# Altium schematic sheet SH_SW3_V1.0.SchDoc
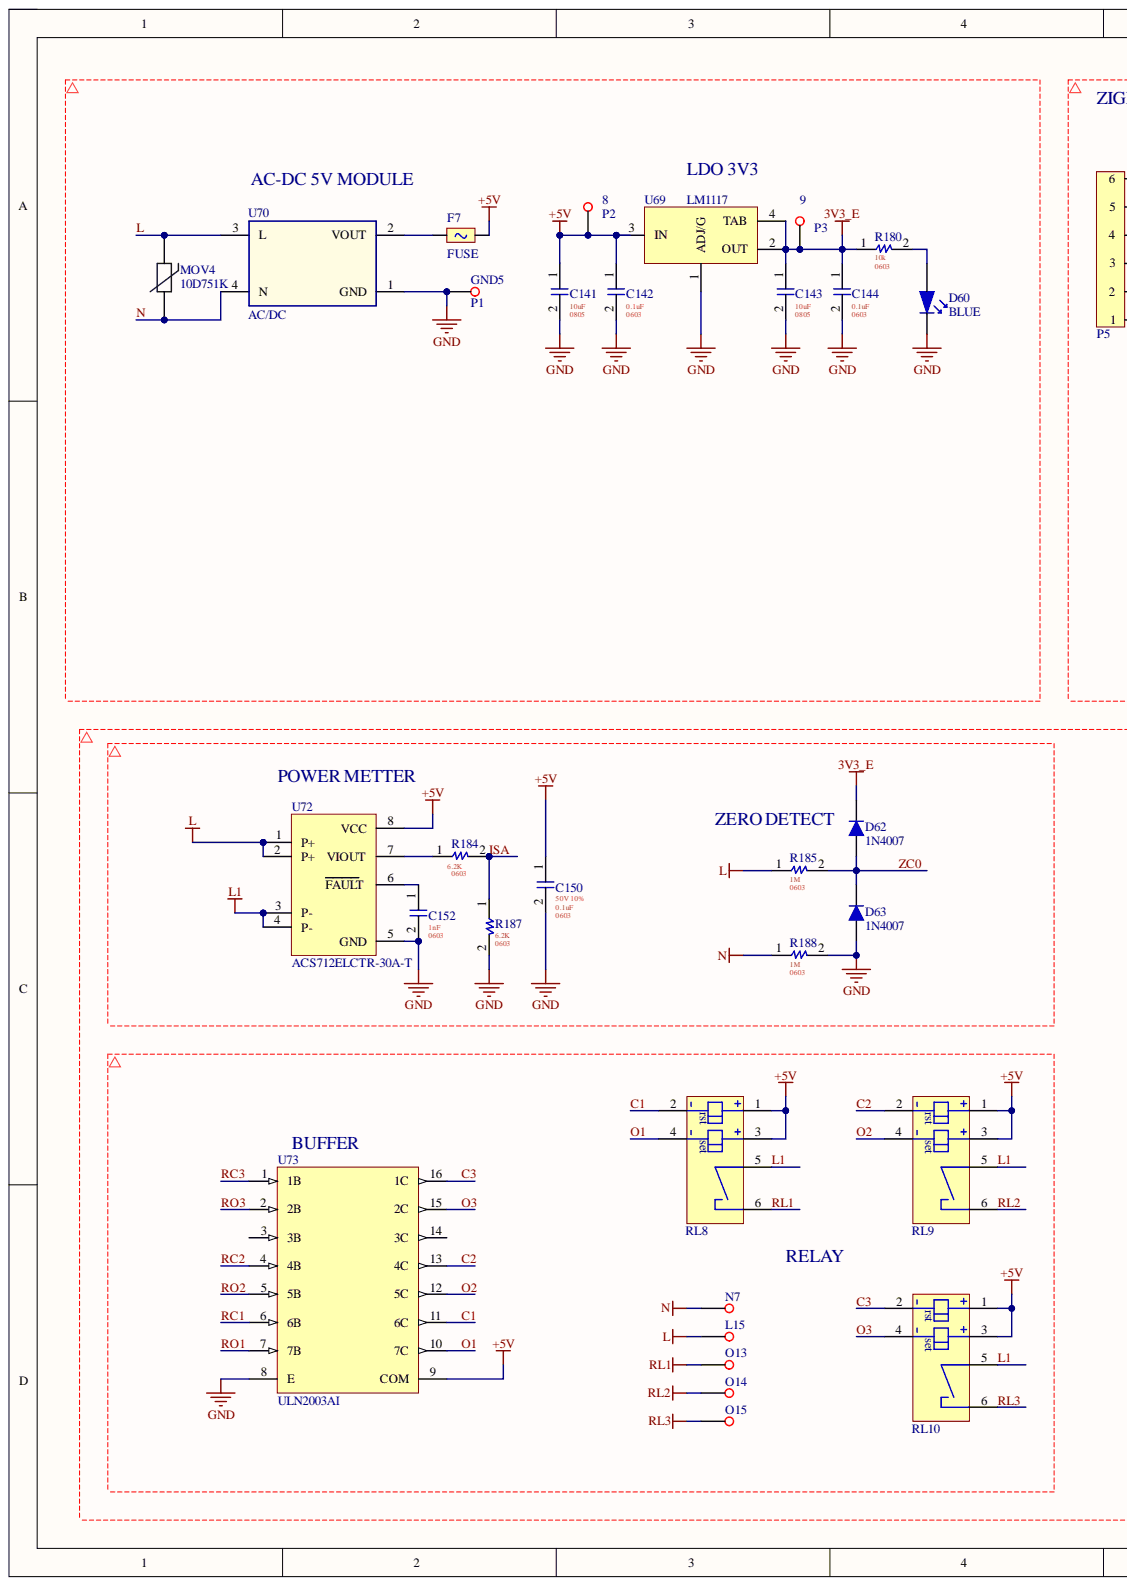
[diagram p0: SH_SW3_V1.0.SchDoc, left]
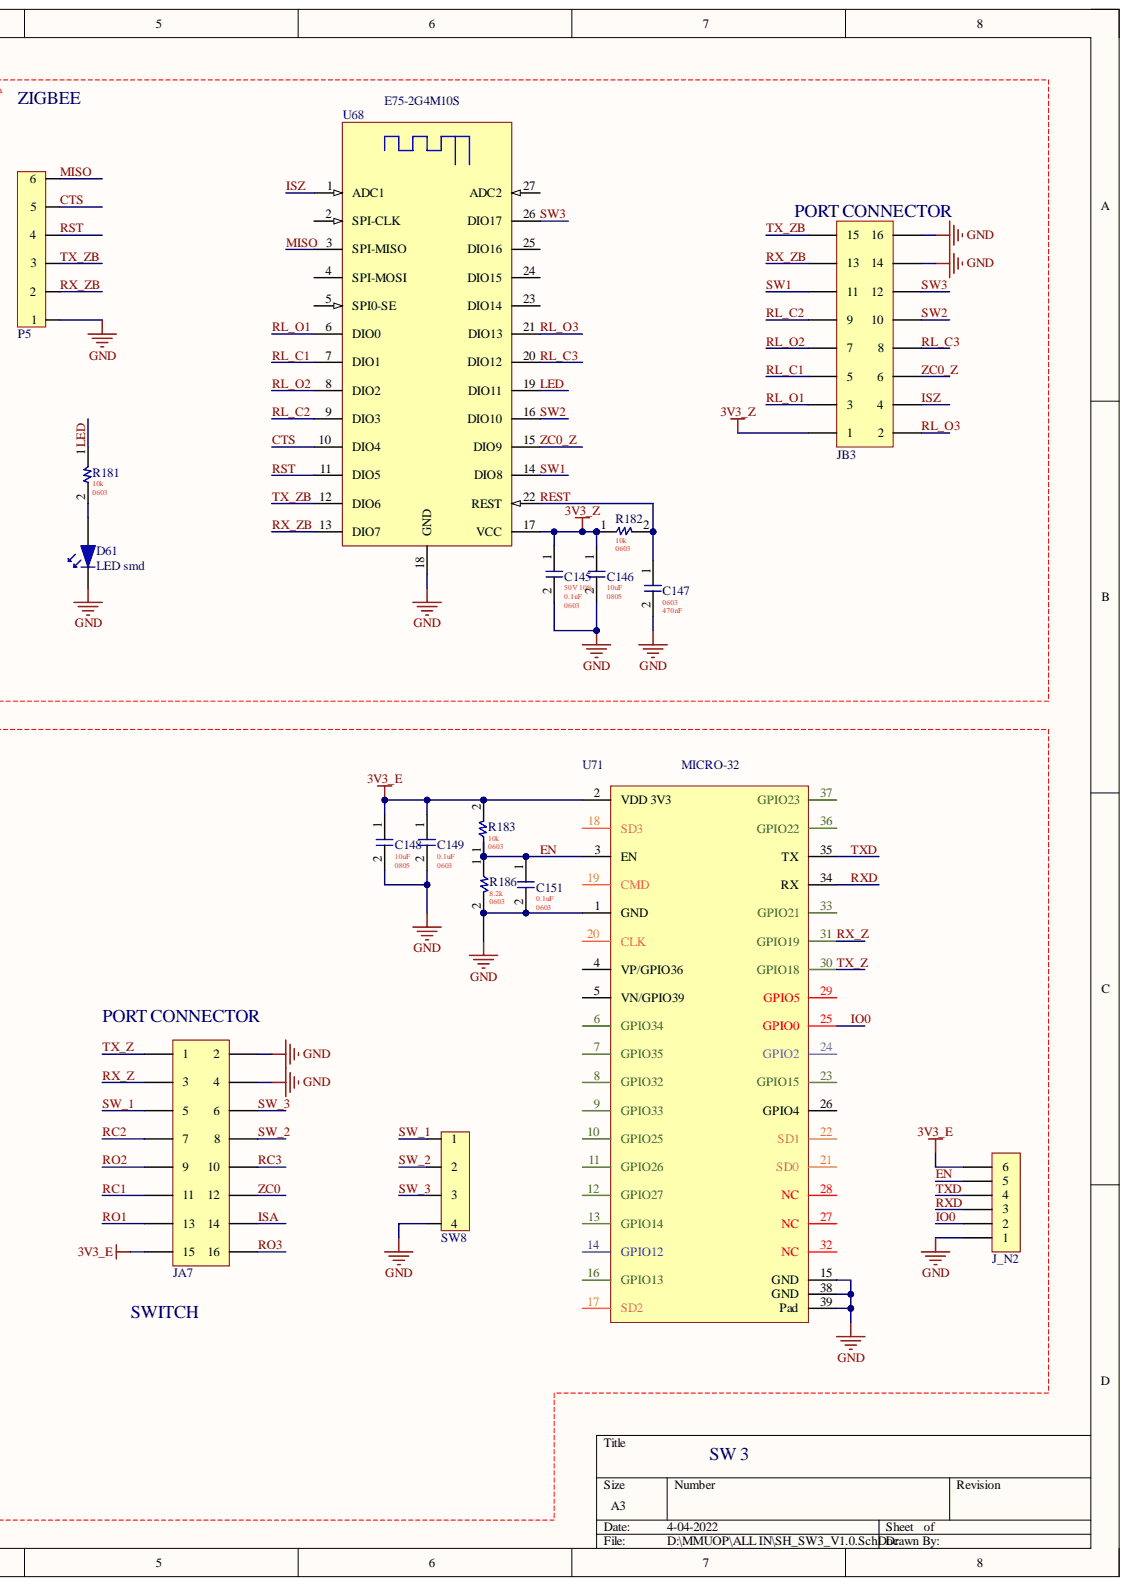
[diagram p1: SH_SW3_V1.0.SchDoc, right]

## Parts
8  P2  [TEXT_POINT]
9  P3  [TEXT_POINT]
C141  10uF  FP: 0805  [0805 C]
C142  0.1uF  FP: 0603  [0603 C]
C143  10uF  FP: 0805  [0805 C]
C144  0.1uF  FP: 0603  [0603 C]
C145  0.1uF  FP: 0603  VOLTAGE + TOLE: 50V 10%  [0603 C]
C146  10uF  FP: 0805  [0805 C]
C147  470nF  FP: 0603  [0603 C]
C148  10uF  FP: 0805  [0805 C]
C149  0.1uF  FP: 0603  [0603 C]
C150  0.1uF  FP: 0603  VOLTAGE + TOLE: 50V 10%  [0603 C]
C151  0.1uF  FP: 0603  [0603 C]
C152  1nF  FP: 0603  [0603 C]
D60  BLUE  [Led 1206]
D61  LED smd  [Led 1206]
D62  1N4007  [SOD-123]
D63  1N4007  [SOD-123]
F7  FUSE  [1812]
GND5  P1  [TEXT_POINT]
J_N2  P6  [P6 - 1.27MM]  (value not drawn)
JA7  rao doi  [P2X8 - 2.0mm - female - SMD]  (value not drawn)
JB3  rao doi  [P2X8 - 2.0mm - SMD]  (value not drawn)
L15  P1  [P1]  (value not drawn)
MOV4  10D751K  [MOV]
N7  P1  [P1]  (value not drawn)
O13  P1  [P1]  (value not drawn)
O14  P1  [P1]  (value not drawn)
O15  P1  [P1]  (value not drawn)
P5  P6  [P6 - 1.27MM]  (value not drawn)
R180  10k  FP: 0603  [0603 R]
R181  10k  FP: 0603  [0603 R]
R182  10k  FP: 0603  [0603 R]
R183  10k  FP: 0603  [0603 R]
R184  value 6.2K  FP: 0603  [0603 R]  (hidden comment: 8.2k)
R185  1M  FP: 0603  [0603 R]
R186  8.2k  FP: 0603  [0603 R]
R187  value 6.2K  FP: 0603  [0603 R]  (hidden comment: 8.2k)
R188  1M  FP: 0603  [0603 R]
RL8  RELAY CHOT  [HFE60]  (value not drawn)
RL9  RELAY CHOT  [HFE60]  (value not drawn)
RL10  RELAY CHOT  [HFE60]  (value not drawn)
SW8  P4  [P4 2.54 RA header]  (value not drawn)
U68  E75-2G4M10S  [ebyte - E75-2G4M10S]
U69  LM1117  [SOT23-4]
U70  AC/DC  [AC-DC 5V K4H24]
U71  MICRO-32  [ESP MICRO 32]
U72  ACS712ELCTR-30A-T  [SOIC 8]
U73  ULN2003AI  [SOIC 16]

## Nets
3V3_E: 9.1 C143.1 C144.1 C148.1 C149.1 D62.2 J_N2.6 JA7.15 R180.1 R183.2 U69.2(OUT) U69.4(TAB) U71.2(VDD_3V3)
3V3_Z: C145.1 C146.1 JB3.1 R182.1 U68.17(VCC)
+5V: 8.1 C141.1 C142.1 C150.1 F7.2 RL8.1 RL8.3 RL9.1 RL9.3 RL10.1 RL10.3 U69.3(IN) U72.8(VCC) U73.9(COM)
GND: C141.2 C142.2 C143.2 C144.2 C145.2 C146.2 C147.2 C148.2 C149.2 C150.2 C151.2 C152.2 D60.2 D61.2 D63.1 GND5.1 J_N2.1 JA7.2 JA7.4 JB3.14 JB3.16 P5.1 R186.2 R187.2 R188.2 SW8.4 U68.18(GND) U69.1(ADJ/G) U70.1(GND) U71.1(GND) U71.15(GND) U71.38(GND) U71.39(Pad) U72.5(GND) U73.8(E)
C1: RL8.2 U73.11(6C)
C2: RL9.2 U73.13(4C)
C3: RL10.2 U73.16(1C)
CTS: P5.5 U68.10(DIO4)
EN: C151.1 J_N2.5 R183.1 R186.1 U71.3(EN)
IO0: J_N2.2 U71.25(GPIO0)
ISA: JA7.14 R184.2 R187.1
ISZ: JB3.4 U68.1(ADC1)
L: L15.1 MOV4.2 R185.1 U70.3(L) U72.1(P+) U72.2(P+)
L1: RL8.5 RL9.5 RL10.5 U72.3(P-) U72.4(P-)
LED: R181.1 U68.19(DIO11)
MISO: P5.6 U68.3(SPI-MISO)
N: MOV4.1 N7.1 R188.1 U70.4(N)
O1: RL8.4 U73.10(7C)
O2: RL9.4 U73.12(5C)
O3: RL10.4 U73.15(2C)
RC1: JA7.11 U73.6(6B)
RC2: JA7.7 U73.4(4B)
RC3: JA7.10 U73.1(1B)
REST: C147.1 R182.2 U68.22(REST)
RL1: O13.1 RL8.6
RL2: O14.1 RL9.6
RL3: O15.1 RL10.6
RL_C1: JB3.5 U68.7(DIO1)
RL_C2: JB3.9 U68.9(DIO3)
RL_C3: JB3.8 U68.20(DIO12)
RL_O1: JB3.3 U68.6(DIO0)
RL_O2: JB3.7 U68.8(DIO2)
RL_O3: JB3.2 U68.21(DIO13)
RO1: JA7.13 U73.7(7B)
RO2: JA7.9 U73.5(5B)
RO3: JA7.16 U73.2(2B)
RST: P5.4 U68.11(DIO5)
RX_Z: JA7.3 U71.31(GPIO19)
RX_ZB: JB3.13 P5.2 U68.13(DIO7)
RXD: J_N2.3 U71.34(RX)
SW1: JB3.11 U68.14(DIO8)
SW2: JB3.10 U68.16(DIO10)
SW3: JB3.12 U68.26(DIO17)
SW_1: JA7.5 SW8.1
SW_2: JA7.8 SW8.2
SW_3: JA7.6 SW8.3
TX_Z: JA7.1 U71.30(GPIO18)
TX_ZB: JB3.15 P5.3 U68.12(DIO6)
TXD: J_N2.4 U71.35(TX)
ZC0: D62.1 D63.2 JA7.12 R185.2
ZC0_Z: JB3.6 U68.15(DIO9)
NetC152_1: C152.1 U72.6(FAULT)
NetD60_1: D60.1 R180.2
NetD61_1: D61.1 R181.2
NetF7_1: F7.1 U70.2(VOUT)
NetR184_1: R184.1 U72.7(VIOUT)

Not connected: U68 2(SPI-CLK) 4(SPI-MOSI) 5(SPI0-SE) 23(DIO14) 24(DIO15) 25(DIO16) 27(ADC2); U71 4(VP/GPIO36) 5(VN/GPIO39) 6(GPIO34) 7(GPIO35) 8(GPIO32) 9(GPIO33) 10(GPIO25) 11(GPIO26) 12(GPIO27) 13(GPIO14) 14(GPIO12) 16(GPIO13) 17(SD2) 18(SD3) 19(CMD) 20(CLK) 21(SD0) 22(SD1) 23(GPIO15) 24(GPIO2) 26(GPIO4) 27(NC) 28(NC) 29(GPIO5) 32(NC) 33(GPIO21) 36(GPIO22) 37(GPIO23); U73 3(3B) 14(3C)

## Library data of the parts
8 9 = P1: Component Kind: Standard; Library Name: Kienlib.SchLib
C141 C143 C146 C148 = 0805 10uF CER: CAP CER 10uF 50V 10% 0805 SMD; VOLTAGE + TOLE: 50V 10%; Component Kind: Standard; ComponentLink1Description: New link; DATE: 04-Mar-21; Library Name: Capacitor.SchLib; PACKAGE: SMD; VALUE: 10uF; CREATOR: MMUOP
C142 C144 C149 C151 = 0603 0.1uF CER: CAP CER 0.1uF 50V 10% 0603 SMD; VOLTAGE + TOLE: 50V 10%; Component Kind: Standard; ComponentLink1Description: New link; DATE: 04-Mar-21; Library Name: Capacitor.SchLib; PACKAGE: SMD; VALUE: 0.1uF; CREATOR: MMUOP
C145 C150 = 0603 0.1uF CER: CAP CER 0.1uF 50V 10% 0603 SMD; Component Kind: Standard; ComponentLink1Description: New link; DATE: 04-Mar-21; Library Name: Capacitor.SchLib; PACKAGE: SMD; VALUE: 0.1uF; CREATOR: MMUOP
C147 = 0603 470nF CER: CAP CER 470nF 50V 10% 0603 SMD; VOLTAGE + TOLE: 50V 10%; Component Kind: Standard; ComponentLink1Description: New link; DATE: 04-Mar-21; Library Name: Capacitor.SchLib; PACKAGE: SMD; VALUE: 470nF; CREATOR: MMUOP
C152 = 0603 1nF CER: CAP CER 1nF 50V 10% 0603 SMD; VOLTAGE + TOLE: 50V 10%; Component Kind: Standard; ComponentLink1Description: New link; DATE: 04-Mar-21; Library Name: Capacitor.SchLib; PACKAGE: SMD; VALUE: 1nF; CREATOR: MMUOP
D60 = LED smd: Component Kind: Standard; Library Name: Kienlib.SchLib
D62 D63 = Diode schottky: Component Kind: Standard; Library Name: Kienlib.SchLib
JA7 JB3 = P2x8: Component Kind: Standard; Library Name: Kienlib.SchLib
MOV4 = VARISTOR: 750V; Component Kind: Standard; Library Name: Kienlib.SchLib
R180-R183 = 0603 10k 1%: 10k 1% 0603 SMD; PN: C98220; Component Kind: Standard; ComponentLink1Description: New link; DATE: 04-Mar-21; Library Name: Res.SchLib; PACKAGE: SMD; VALUE: 10k; VOLTAGE + TOLE: 1%; CREATOR: MMUOP
R184 R186 R187 = 0603 8.2k 1%: 8.2k 1% 0603 SMD; Component Kind: Standard; ComponentLink1Description: New link; DATE: 04-Mar-21; Library Name: Res.SchLib; PACKAGE: SMD; VOLTAGE + TOLE: 1%; CREATOR: MMUOP
  R186: VALUE: 8.2k
R185 R188 = 0603 1M 1%: 1M 1% 0603 SMD; Component Kind: Standard; ComponentLink1Description: New link; DATE: 04-Mar-21; Library Name: Res.SchLib; PACKAGE: SMD; VALUE: 1M; VOLTAGE + TOLE: 1%; CREATOR: MMUOP
U68 = ebyte - E75-2G4M10S: Component Kind: Standard; Description: E75-2G4M10S; Library Name: Kienlib.SchLib
U71 = ESP MICRO-32_FIX: Component Kind: Standard; Description: MICRO-32; Library Name: Kienlib.SchLib
U72 = ACS71240: ACS712ELCTR-20A  SOIC 8; Component Kind: Standard; ComponentLink1Description: New link; ComponentLink1URL: https://banlinhkiendientu.vn/acs712t/; Library Name: Kienlib.SchLib
U73 = ULN2003AI: TRANS 7NPN DARL 50V 0.5A 16SO; Component Kind: Standard; Supplier 1: Digi-Key; Supplier Part Number 1: 296-29404-1-ND; Library Name: Kienlib.SchLib
D61 = LED smd: Component Kind: Standard; Library Name: Kienlib.SchLib
F7 = FUSE: Component Kind: Standard; Library Name: Kienlib.SchLib
GND5 L15 N7 O13-O15 = P1: Component Kind: Standard; Library Name: Kienlib.SchLib
J_N2 P5 = P6: Component Kind: Standard; Library Name: Kienlib.SchLib
RL8-RL10 = RELAY CHOT: Component Kind: Standard; Library Name: Kienlib.SchLib
SW8 = P4: Component Kind: Standard; Library Name: Kienlib.SchLib
U69 = LM1117: Component Kind: Standard; Library Name: Kienlib.SchLib
U70 = AC/DC: Component Kind: Standard; Library Name: Kienlib.SchLib
